# Altium schematic sheet Antenna and LoRa.SchDoc("Antenna and LoRa")
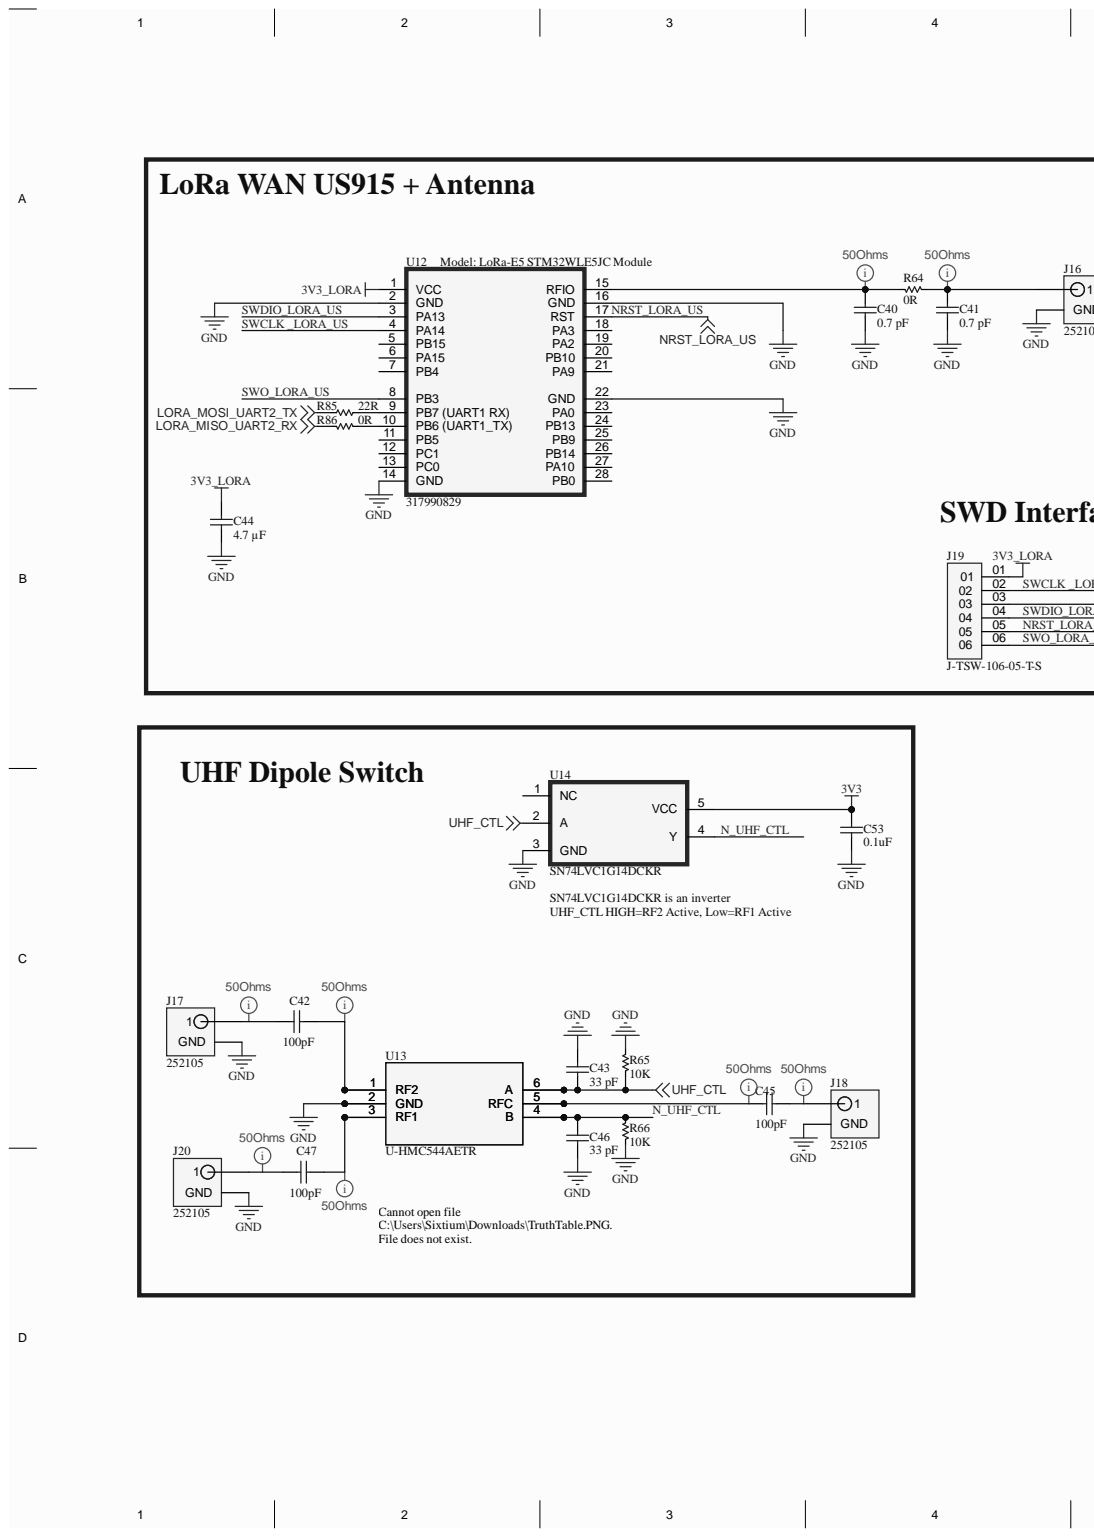
[diagram p0: Antenna and LoRa.SchDoc, left]
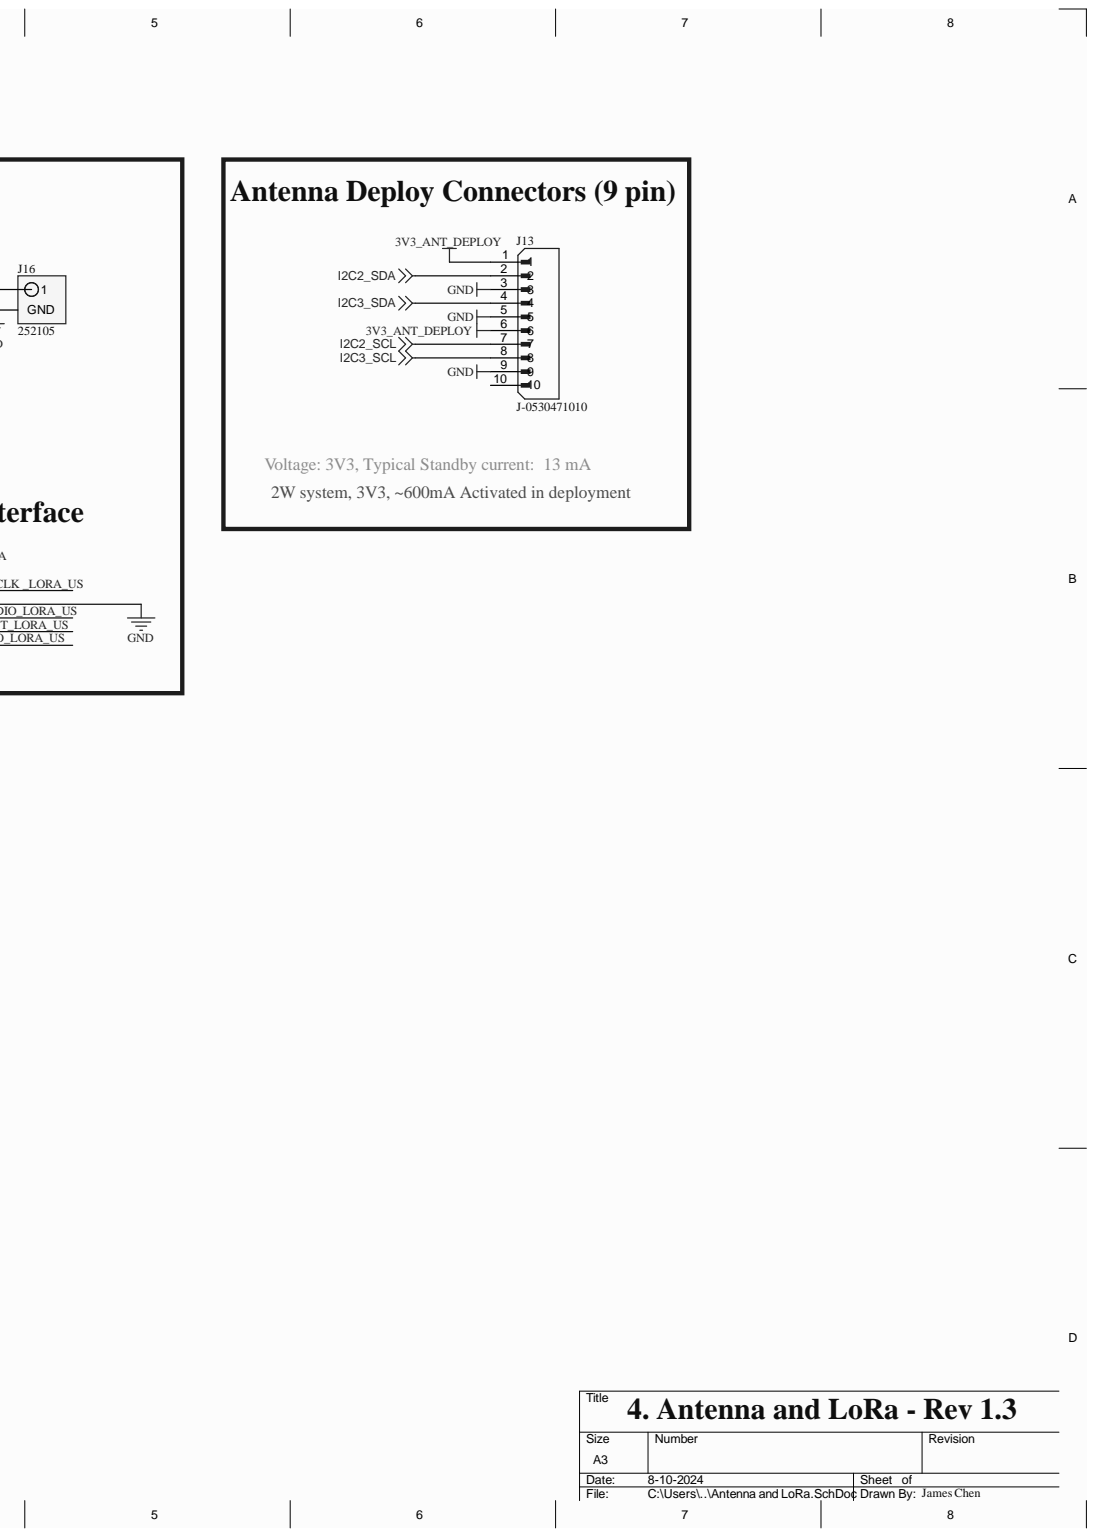
[diagram p1: Antenna and LoRa.SchDoc, right]

## Parts
C40  0.7 pF  [0603]
C41  0.7 pF  [0603]
C42  100pF  [0603]
C43  33 pF  [0603]
C44  4.7 µF  [0603]
C45  100pF  [0603]
C46  33 pF  [0603]
C47  100pF  [0603]
C53  0.1uF  [0603]
J13  J-0530471010  [J-0530471010]
J16  252105  [J-252105]
J17  252105  [J-252105]
J18  252105  [J-252105]
J19  J-TSW-106-05-T-S  [J-TSW-106-05-T-S]
J20  252105  [J-252105]
R64  0R  [0603]
R65  10K  [0603]
R66  10K  [0603]
R85  22R  [0603]
R86  0R  [0603]
U12  317990829  [U-LORA-E5]
U13  U-HMC544AETR  [U-HMC544AETR]
U14  SN74LVC1G14DCKR  [U-SN74LVC1G14DCKR]

## Nets
3V3: C53.2 U14.5(VCC)
3V3_ANT_DEPLOY: J13.1 J13.6
3V3_LORA: C44.2 J19.01 U12.1(VCC)
GND: C40.1 C41.1 C43.2 C44.1 C46.1 C53.1 J13.3 J13.5 J13.9 J16.0 J17.0 J18.0 J19.03 J20.0 R65.2 R66.1 U12.2(GND) U12.14(GND) U12.16(GND) U12.22(GND) U13.2(GND) U14.3(GND)
I2C2_SCL: J13.7
I2C2_SDA: J13.2
I2C3_SCL: J13.8
I2C3_SDA: J13.4
LORA_MISO_UART2_RX: R86.1
LORA_MOSI_UART2_TX: R85.2
UHF_CTL: C43.1 R65.1 U13.6(A) U14.2(A)
N_UHF_CTL: C46.2 R66.2 U13.4(B) U14.4(Y)
NRST_LORA_US: J19.05 U12.17(RST)
SWCLK _LORA_US: J19.02 U12.4(PA14)
SWDIO_LORA_US: J19.04 U12.3(PA13)
SWO_LORA_US: J19.06 U12.8(PB3)
NetC40_2: C40.2 R64.1 U12.15(RFIO)
NetC41_2: C41.2 J16.1 R64.2
NetC42_1: C42.1 J17.1
NetC42_2: C42.2 U13.1(RF2)
NetC45_1: C45.1 U13.5(RFC)
NetC45_2: C45.2 J18.1
NetC47_1: C47.1 J20.1
NetC47_2: C47.2 U13.3(RF1)
NetR85_1: R85.1 U12.9
NetR86_2: R86.2 U12.10(PB6_(UART1_TX))

Not connected: J13 10; U12 5(PB15) 6(PA15) 7(PB4) 11(PB5) 12(PC1) 13(PC0) 18(PA3) 19(PA2) 20(PB10) 21(PA9) 23(PA0) 24(PB13) 25(PB9) 26(PB14) 27(PA10) 28(PB0); U14 1(NC)

## Library data of the parts
C40 C41 = CC-710-K-50V-X7R-0603: CAP CER 0.7PF 50V NP0 0603; Manufacturer: KEMET; Manufacturer Part #: CBR06C708B5GAC; Component Kind: Standard; ComponentLink1description: Datasheet; ComponentLink1URL: https://ds.yuden.co.jp/TYCOMPAS/ut/detail?pn=TMK105BJ104KV-F%20%20&u=M; ComponentLink2description: Manufacturer Website; ComponentLink2URL: https://www.yuden.co.jp/or/; Distributor: Digikey; Distributor Part #: 399-CBR06C708B5GACCT-ND; Value: 0.7 pF; Library Name: UHF Radio rev1.0.SchLib
C42 C45 C47 = CC-104-K-25V-X5R-0402: CAP CER 100PF 50V X7R 0603; Manufacturer: YAGEO; Manufacturer Part #: CC0603KRX7R9BB101; Component Kind: Standard; ComponentLink1description: Datasheet; ComponentLink1URL: https://ds.yuden.co.jp/TYCOMPAS/ut/detail?pn=TMK105BJ104KV-F%20%20&u=M; ComponentLink2description: Manufacturer Website; ComponentLink2URL: https://www.yuden.co.jp/or/; Distributor: Digikey; Distributor Part #: 311-3601-1-ND; Library Name: RS2D.SchLib; Part Description: CAP CER 100PF 50V X7R 0603; Value: 100pF
C43 C46 = CC-339-K-50V-X7R-0603: CAP CER 33PF 50V X7R 0603; Manufacturer: KEMET; Manufacturer Part #: C0603C330K5RAC7867; Component Kind: Standard; ComponentLink1description: Datasheet; ComponentLink1URL: https://ds.yuden.co.jp/TYCOMPAS/ut/detail?pn=TMK105BJ104KV-F%20%20&u=M; ComponentLink2description: Manufacturer Website; ComponentLink2URL: https://www.yuden.co.jp/or/; Distributor: Digikey; Distributor Part #: 399-C0603C330K5RAC7867CT-ND; Part Description: CAP CER 33PF 50V X7R 0603; Value: 33 pF; Library Name: OBC Development Board.SchLib
C44 = CC-105-K-25V-X7R-0603: CAP CER 4.7UF 16V X7R 0603; Manufacturer: Murata Electronics; Manufacturer Part #: GRM188Z71C475KE21J; Component Kind: Standard; Distributor: Digikey; Distributor Part #: 490-GRM188Z71C475KE21JCT-ND; Library Name: RS2D.SchLib; Value: 4.7 µF
C53 = CC-100nF-25V-X7R-0603: 25V 100nF X7R ±10% 0603 Multilayer Ceramic Capacitors MLCC - SMD/SMT ROHS; Manufacturer: Murata Electronics; Manufacturer Part #: GCJ188R71E104KA12D; Max Exposed Voltage: 6; Rated Voltage: 25; Component Kind: Standard; ComponentLink1description: Datasheet; ComponentLink1URL: https://ds.yuden.co.jp/TYCOMPAS/ut/detail?pn=TMK105BJ104KV-F%20%20&u=M; ComponentLink2description: Manufacturer Website; ComponentLink2URL: https://www.yuden.co.jp/or/; Distributor: LCSC; Distributor Part #: C354391; Highest Rated Operating Temperature: 125; Lowest Rated Operating Temperature: -55; Part Description: 25V 100nF X7R ±10% 0603 Multilayer Ceramic Capacitors MLCC - SMD/SMT ROHS; Value: 0.1uF; Library Name: OBC Development Board.SchLib
J13 = J-0530471010: CONN HEADER VERT 10POS 1.25MM; Manufacturer: Molex; Component Kind: Standard; Distributor: Digikey; Distributor Part #: WM1739-ND; Manufacturer Part #: 0530471010; Library Name: OBC Development Board.SchLib
J16-J18 J20 = J-252105: CONN MCX RCPT STR 50 OHM PCB; Manufacturer: Amphenol RF; Component Kind: Standard; Distributor: Digikey; Distributor Part #: ACX1262-ND; Manufacturer Part #: 252105; Library Name: OBC Development Board.SchLib
J19 = J-TSW-106-05-T-S: CONN HEADER VERT 6POS 2.54MM; Distrbutor part #: SAM12323-ND; Manufacturer: Samtec; Distrbutor: None; Component Kind: Standard; Manufacturer Part #: TSW-106-05-T-S; Price: None; Library Name: OBC Development Board.SchLib
R64 R86 = RT-0R00-F-1/10W-0603: 0 Ohms Jumper 0.1W, 1/10W Chip Resistor 0603 (1608 Metric) Automotive AEC-Q200 Thick Film; Manufacturer: Stackpole Electronics Inc; Manufacturer Part #: RMCF0603ZT0R00; Component Kind: Standard; ComponentLink1description: Datasheet; ComponentLink1URL: https://industrial.panasonic.com/ww/products/resistors/chip-resistors/general-purpose-chip-resistors/models/ERJ2GE0R00X; ComponentLink2description: Manufacturer Website; ComponentLink2URL: https://industrial.panasonic.com/ww/products/resistors/chip-resistors/general-purpose-chip-resistors/models/ERJ2GE0R00X; Distributor: Digikey; Distributor Part #: RMCF0603ZT0R00CT-ND; Part Description: 0 Ohms Jumper 0.1W, 1/10W Chip Resistor 0603 (1608 Metric) Automotive AEC-Q200 Thick Film; Value: 0R; Library Name: OBC Development Board.SchLib
R65 R66 = RT-10K0-F-1/16W-0603: 10 kOhms ±0.1% 0.063W, 1/16W Chip Resistor 0603 (1608 Metric) - Thin Film; Manufacturer: TE Connectivity Passive Product; Manufacturer Part #: CPF0603B10KE; Component Kind: Standard; ComponentLink1description: Datasheet; ComponentLink1URL: https://www.vishay.com/docs/20035/dcrcwe3.pdf; ComponentLink2description: Manufacturer Website; ComponentLink2URL: https://www.vishay.com/; Distributor: Digikey; Distributor Part #: A119886CT-ND; Part Description: 10 kOhms ±0.1% 0.063W, 1/16W Chip Resistor 0603 (1608 Metric) - Thin Film; Value: 10K; Library Name: OBC Development Board.SchLib
R85 = RT-22R0-F-1/16W-0603: 22 Ohms ±0.5% 0.063W, 1/16W Chip Resistor 0603 (1608 Metric) - Thin Film; Manufacturer: Susumu; Manufacturer Part #: RR0816Q-220-D; Component Kind: Standard; ComponentLink1description: Datasheet; ComponentLink1URL: https://www.vishay.com/docs/20035/dcrcwe3.pdf; ComponentLink2description: Manufacturer Website; ComponentLink2URL: https://www.vishay.com/; Distributor: Digikey; Distributor Part #: RR08Q22DCT-ND; Part Description: 22 Ohms ±0.5% 0.063W, 1/16W Chip Resistor 0603 (1608 Metric) - Thin Film; Value: 22R; Library Name: OBC Development Board.SchLib
U12 = U-LORA-E5: LORA-E5 WIRELESS MODULE (TAPE RE; Manufacturer: Seeed Technology Co., Ltd; Component Kind: Standard; Distributor: Digikey; Distributor Part #: 1597-317990829CT-ND; Manufacturer Part #: 317990829; Library Name: OBC Development Board.SchLib
U13 = U-HMC544AETR: 0Hz~4GHz 23dB 0.7dB SOT-23-6 RF Switches ROHS; Manufacturer: Analog Devices; Component Kind: Standard; Distributor: LCSC; Distributor Part #: C579555; LCSC Part #: C579555; Manufacturer Part #: HMC544AETR; Library Name: OBC Development Board.SchLib
U14 = U-SN74LVC1G14DCKR: IC INVERT SCHMITT 1CH 1IN SC70; Manufacturer: Texas Instruments; Component Kind: Standard; Distributor: Digikey; Distributor Part #: 296-11608-1-ND; LCSC Part #: C35740; Manufacturer Part #: SN74LVC1G14DCKR; Library Name: OBC Development Board.SchLib
